# Altium schematic sheet l2-l3.SchDoc
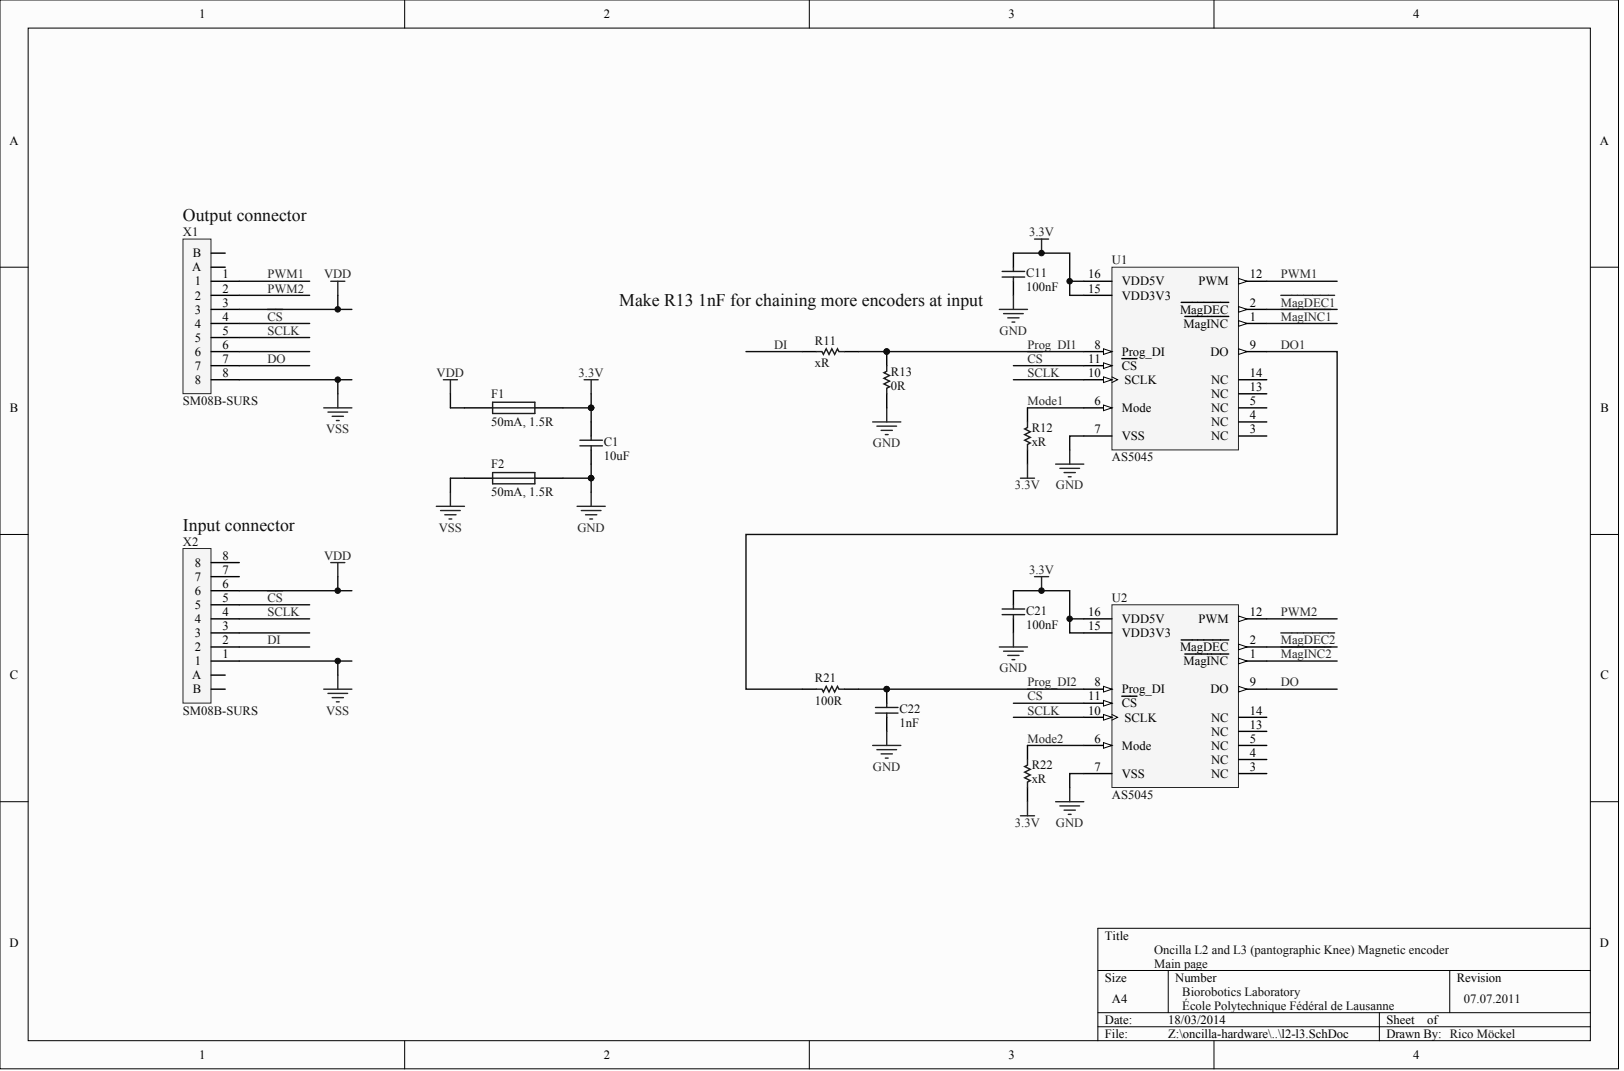
[diagram p0: l2-l3.SchDoc]

## Parts
C1  10uF
C11  100nF
C21  100nF
C22  1nF
F1  50mA, 1.5R
F2  50mA, 1.5R
R11  xR
R12  xR
R13  0R
R21  100R
R22  xR
U1  AS5045
U2  AS5045
X1  SM08B-SURS
X2  SM08B-SURS

## Nets
3.3V: C1.2 C11.1 C21.1 F1.2 R12.1 R22.1 U1.15(VDD3V3) U1.16(VDD5V) U2.15(VDD3V3) U2.16(VDD5V)
GND: C1.1 C11.2 C21.2 C22.2 F2.2 R13.1 U1.7(VSS) U2.7(VSS)
VDD: F1.1 X1.3 X2.6
VSS: F2.1 X1.8 X2.1
~{CS}: U1.11(CS) U2.11(CS) X1.4 X2.5
DI: R11.1 X2.2
DO: U2.9(DO) X1.7
DO1: R21.1 U1.9(DO)
~{MAGDEC1}: U1.2(MagDEC)
~{MAGDEC2}: U2.2(MagDEC)
~{MAGINC1}: U1.1(MagINC)
~{MAGINC2}: U2.1(MagINC)
MODE1: R12.2 U1.6(Mode)
MODE2: R22.2 U2.6(Mode)
PROG_DI1: R11.2 R13.2 U1.8(Prog_DI)
PROG_DI2: C22.1 R21.2 U2.8(Prog_DI)
PWM1: U1.12(PWM) X1.1
PWM2: U2.12(PWM) X1.2
SCLK: U1.10(SCLK) U2.10(SCLK) X1.5 X2.4

Not connected: U1 3(NC) 4(NC) 5(NC) 13(NC) 14(NC); U2 3(NC) 4(NC) 5(NC) 13(NC) 14(NC); X1 6 A B; X2 3 7 8 A B

## Library data of the parts
C1 C11 C21 C22 F1 F2 R11-R13 R21 R22 U1 U2 X1 X2 = (no symbol name)
  C1 C11 C21 C22: Published: 8-Jun-2000; LatestRevisionDate: 17-Jul-2002; LatestRevisionNote: Re-released for DXP Platform.; PackageReference: 0603
  F1 F2: Published: 8-Jun-2000; LatestRevisionDate: 17-Jul-2002; LatestRevisionNote: Re-released for DXP Platform.; PackageReference: PIN-W2/E2.8
  R11-R13 R21 R22: Published: 8-Jun-2000; LatestRevisionDate: 17-Jul-2002; LatestRevisionNote: Re-released for DXP Platform.; PackageReference: AXIAL-0.3
  C1 C11 C21 C22 F1 F2 R11-R13 R21 R22: Publisher: Altium Limited
